# Altium schematic sheet Sheet1.SchDoc
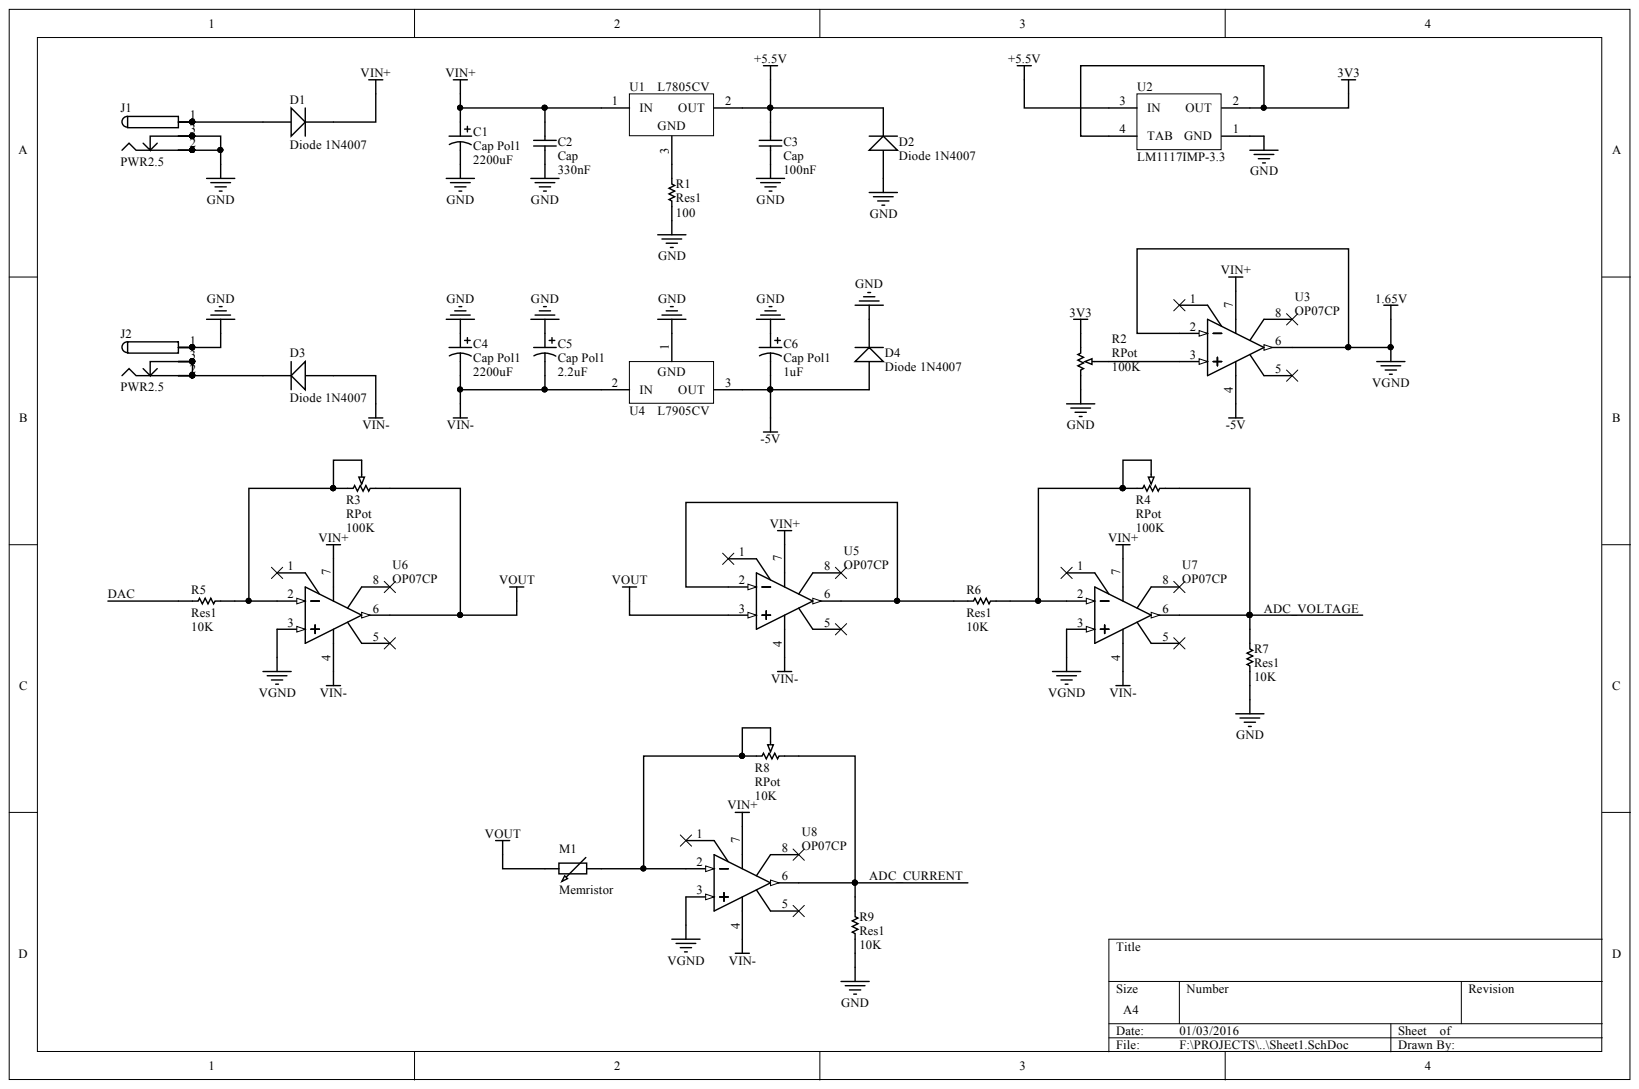
[diagram p0: Sheet1.SchDoc]

## Parts
C1  Cap Pol1  value 2200uF  [RB7.6-15]
C2  Cap  value 330nF  [RAD-0.3]
C3  Cap  value 100nF  [RAD-0.3]
C4  Cap Pol1  value 2200uF  [RB7.6-15]
C5  Cap Pol1  value 2.2uF  [RB7.6-15]
C6  Cap Pol1  value 1uF  [RB7.6-15]
D1  Diode 1N4007  [DO-41]
D2  Diode 1N4007  [DO-41]
D3  Diode 1N4007  [DO-41]
D4  Diode 1N4007  [DO-41]
J1  PWR2.5  [KLD-0202]
J2  PWR2.5  [KLD-0202]
M1  Memristor  [AXIAL-0.6]
R1  Res1  value 100  [AXIAL-0.3]
R2  RPot  value 100K  [VR5]
R3  RPot  value 100K  [VR5]
R4  RPot  value 100K  [VR5]
R5  Res1  value 10K  [AXIAL-0.3]
R6  Res1  value 10K  [AXIAL-0.3]
R7  Res1  value 10K  [AXIAL-0.3]
R8  RPot  value 10K  [VR5]
R9  Res1  value 10K  [AXIAL-0.3]
U1  L7805CV  [TO220ABN]
U2  LM1117IMP-3.3  [MP04A_N]
U3  OP07CP  [N-8_A]
U4  L7905CV  [TO220V]
U5  OP07CP  [N-8_A]
U6  OP07CP  [N-8_A]
U7  OP07CP  [N-8_A]
U8  OP07CP  [N-8_A]

## Nets
1.65V: U3.2 U3.6 U6.3 U7.3 U8.3
3V3: R2.1 U2.2(OUT) U2.4(TAB)
+5.5V: C3.2 D2.2 U1.2 U2.3(IN)
-5V: C6.2 D4.1 U3.4 U4.3
GND: C1.2 C2.1 C3.1 C4.1 C5.1 C6.1 D2.1 D4.2 J1.2 J1.2A J1.2B J1.3 J1.3A J1.3B J2.1 J2.1A J2.1B R1.1 R2.2 R7.2 R9.2 U2.1(GND) U4.1
VIN+: C1.1 C2.2 D1.2 U1.1 U3.7 U5.7 U6.7 U7.7 U8.7
VIN-: C4.2 C5.2 D3.1 U4.2 U5.4 U6.4 U7.4 U8.4
VOUT: M1.2 R3.1 U5.3 U6.6
ADC_CURRENT: R8.1 R9.1 U8.6
ADC_VOLTAGE: R4.1 R7.1 U7.6
DAC: R5.2
NetD1_1: D1.1 J1.1 J1.1A J1.1B
NetD3_2: D3.2 J2.2 J2.2A J2.2B J2.3 J2.3A J2.3B
NetM1_1: M1.1 R8.2 R8.3 U8.2
NetR1_2: R1.2 U1.3
NetR2_3: R2.3 U3.3
NetR3_2: R3.2 R3.3 R5.1 U6.2
NetR4_2: R4.2 R4.3 R6.1 U7.2
NetR6_2: R6.2 U5.2 U5.6

Not connected: U3 1 5 8; U5 1 5 8; U6 1 5 8; U7 1 5 8; U8 1 5 8

## Library data of the parts
C1 C4-C6 = Cap Pol1: Polarized Capacitor (Radial); Component Kind: Standard; LatestRevisionDate: 17-Jul-2002; LatestRevisionNote: Re-released for DXP Platform.; Library Name: Miscellaneous Devices.IntLib; PackageDescription: Polarized Capacitor (Radial); 2 Leads; PackageReference: RB7.6-15; Published: 8-Jun-2000; Signal Integrity: Cap Pol; Simulation: CAP; Publisher: Altium Limited
C2 C3 = Cap: Capacitor; Component Kind: Standard; LatestRevisionDate: 17-Jul-2002; LatestRevisionNote: Re-released for DXP Platform.; Library Name: Miscellaneous Devices.IntLib; PackageDescription: Radial Cap, Thru-Hole; 2 Leads; 0.3 in Pin Spacing; PackageReference: RAD-0.3; Published: 8-Jun-2000; Signal Integrity: Cap; Simulation: CAP; Publisher: Altium Limited
D1-D4 = Diode 1N4007: 1 Amp General Purpose Rectifier; Code_JEDEC: DO-204-AL; Component Kind: Standard; ComponentLink1Description: Manufacturer Link; ComponentLink1URL: http://www.fairchildsemi.com/; ComponentLink2Description: Datasheet; ComponentLink2URL: http://www.fairchildsemi.com/ds/1N%2F1N4001.pdf; DatasheetVersion: 1998; LatestRevisionDate: 17-Jul-2002; LatestRevisionNote: Re-released for DXP Platform.; Library Name: Miscellaneous Devices.IntLib; PackageDescription: Diode, Axial; Body 5.2 x 2.7 mm (LxDia. max), Lead Dia. 0.86 mm (max); PackageReference: DO-41; PackageVersion: Aug-1999; Published: 8-Jun-2000; Signal Integrity: 1N4007; Simulation: 1N4007; Publisher: Altium Limited
J1 J2 = PWR2.5: Low Voltage Power Supply Connector; Component Kind: Standard; ComponentLink1Description: Manufacturer Link; ComponentLink1URL: http://domino.kycon.com/internet/Products.html; DatasheetDocument: 09-May-2002; Library Name: Miscellaneous Connectors.IntLib; Published: 17-Dec-2003; Signal Integrity: Connector; Publisher: Altium Limited
M1 = Res Adj2: Variable Resistor; Set Position: 0.5; Component Kind: Standard; LatestRevisionDate: 17-Jul-2002; LatestRevisionNote: Re-released for DXP Platform.; Library Name: Miscellaneous Devices.IntLib; PackageDescription: Axial Device, Thru-Hole; 2 Leads; 0.6 in Pin Spacing; PackageReference: AXIAL-0.6; Published: 8-Jun-2000; Signal Integrity: Res Adj; Simulation: VRES; Publisher: Altium Limited
R1 R5-R7 R9 = Res1: Resistor; Component Kind: Standard; LatestRevisionDate: 17-Jul-2002; LatestRevisionNote: Re-released for DXP Platform.; Library Name: Miscellaneous Devices.IntLib; PackageDescription: Axial Device, Thru-Hole; 2 Leads; 0.3 in Pin Spacing; PackageReference: AXIAL-0.3; Published: 8-Jun-2000; Signal Integrity: Res; Simulation: RESISTOR; Publisher: Altium Limited
R2-R4 R8 = RPot: Potentiometer; Set Position: 0.5; Sim Note: Enter the Resistance value and the set point into parameter fields.; Component Kind: Standard; LatestRevisionDate: 17-Jul-2002; LatestRevisionNote: Re-released for DXP Platform.; Library Name: Miscellaneous Devices.IntLib; PackageDescription: Variable Resistor, Thru-Hole; 3 Leads; PackageReference: VR5; Published: 8-Jun-2000; Simulation: POT; Publisher: Altium Limited
U1 = L7805CV: Positive Voltage Regulator; Note: Tab is connected to pin 3 (GND).; Component Kind: Standard; ComponentLink1Description: Manufacturer Link; ComponentLink1URL: http://www.st.com/; DatasheetVersion: Dec-1998; LatestRevisionDate: 17-Jul-2002; LatestRevisionNote: Re-released for DXP Platform.; Library Name: ST Power Mgt Voltage Regulator.IntLib; PackageDescription: TO, Thru-Hole, Vertical, Heatsink Mounted; 3 In-Line Leads; Pitch 2.54 mm; Pin sequence 1, 3, 2; PackageReference: TO220ABN; PackageVersion: Jun-1998; Published: 24-Aug-2001; Publisher: Altium Limited
U2 = LM1117IMP-3.3: 800mA Low-Dropout Linear Regulator, 4-pin SOT-223; Manufacturer: National Semiconductor; CompType: LDO IC; PackageTopMarking: XYTT*N05B; PowerWise: N; Code_IPC: SOT223-4; Code_JEDEC: TO-261-AA; Component Kind: Standard; ComponentLink1Description: LM1117 Datasheet; ComponentLink1URL: http://www.national.com/ds/LM/LM1117.pdf; ComponentLink2Description: LM1117 Web Page; ComponentLink2URL: http://www.national.com/pf/LM/LM1117.html; ComponentLink3Description: MP04A Package Page; ComponentLink3URL: http://www.national.com/packaging/folders/mp04a.html; DatasheetVersion: Apr-2006; Library Name: NSC LDO.IntLib; PackageDescription: Molded SOT-223, 6.50 x 3.56 x 1.6mm Body, 4 Lead, 2.3mm Pitch; PackageReference: MP04A; PackageVersion: revB, Apr-2003; PartNumber: LM1117IMP-3.3; PinCount: 4; Published: 4-Dec-2008; RoHS: N; Supplier 1: Farnell; Supplier Part Number 1: 7614123; Publisher: Altium Limited
U3 U5-U8 = OP07CP: Ultra-Low Offset Voltage Operational Amplifier; Note: Pin 5 is not connected.; Code_JEDEC: MO-001-AN; Component Kind: Standard; ComponentLink1Description: Manufacturer Link; ComponentLink1URL: http://www.analog.com/en/prod/0,,759_786_OP07%2C00.html; ComponentLink2Description: Datasheet; ComponentLink2URL: http://www.analog.com/UploadedFiles/Data_Sheets/39161232478959OP07_c.pdf; DatasheetVersion: 1992; LatestRevisionDate: 04-Mar-2005; LatestRevisionNote: Stylized 3D Model Added.; Library Name: AD Operational Amplifier.IntLib; PackageDescription: DIP; 8 Leads; Row Spacing 7.62 mm; Pitch 2.54 mm; PackageReference: N-8_A; PCB3D: N-8A; Published: 8-Jun-2000; Publisher: Altium Limited
U4 = L7905CV: Negative Voltage Regulator; Note: Tab is connected to pin 3 (IN).; Component Kind: Standard; ComponentLink1Description: Manufacturer Link; ComponentLink1URL: http://www.st.com/; ComponentLink2Description: Datasheet; ComponentLink2URL: http://www.st.com/stonline/products/literature/ds/2149/l7905c.pdf; DatasheetVersion: Apr-1997; LatestRevisionDate: 17-Jul-2002; LatestRevisionNote: Re-released for DXP Platform.; Library Name: ST Power Mgt Voltage Regulator.IntLib; PackageDescription: TO, Thru-Hole, Vertical, Heatsink Mounted; 3 In-Line Leads; Pitch 2.54 mm; Pin sequence 1, 3, 2; PackageReference: TO220V; Published: 24-Aug-2001; Publisher: Altium Limited
